# Altium schematic sheet Power.SchDoc(Power)
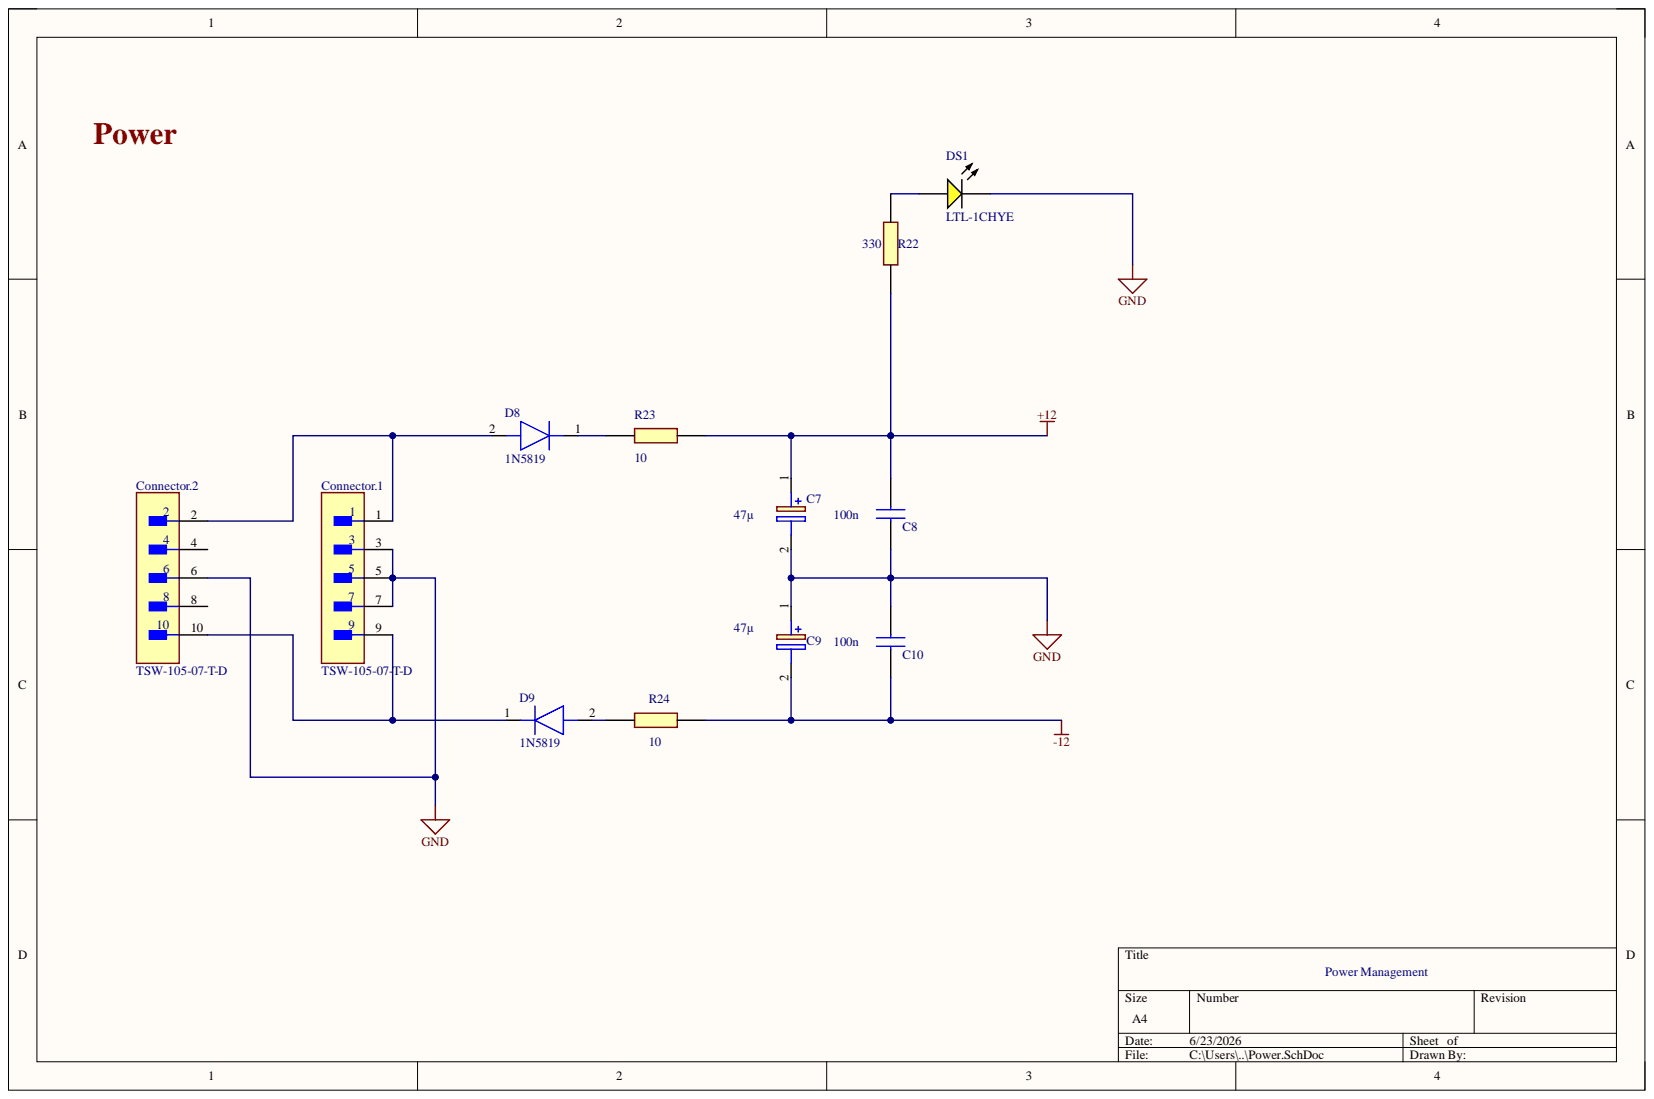
[diagram p0: Power.SchDoc]

## Parts
C7  value 47µ  [CAPPRD350W60D800H1250]  (hidden comment: ESH476M050AG3KA)
C8  value 100n  [K104K15X7RF5TH5]  (hidden comment: K104K15X7RF5TH5)
C9  value 47µ  [CAPPRD350W60D800H1250]  (hidden comment: ESH476M050AG3KA)
C10  value 100n  [K104K15X7RF5TH5]  (hidden comment: K104K15X7RF5TH5)
Connector  TSW-105-07-T-D  [FP-TSW-105-07-T-D-MFG]  (parts .1,.2)
D8  1N5819  [DIOAD1414W86L464D238]
D9  1N5819  [DIOAD1414W86L464D238]
DS1  LTL-1CHYE  [LTST-LTL-1CHYE]
R22  value 330  [RESAD1590W60L630D240]  (hidden comment: MFR-25FTF52-600K)
R23  value 10  [RESAD1590W60L630D240]  (hidden comment: MFR-25FTF52-600K)
R24  value 10  [RESAD1590W60L630D240]  (hidden comment: MFR-25FTF52-600K)

## Nets
+12: C7.1 C8.2 R22.2 R23.2
-12: C9.2 C10.1 R24.2
GND: C7.2 C8.1 C9.1 C10.2 Connector.3 Connector.5 Connector.6 Connector.7 DS1.2
NetConnector_1: Connector.1 Connector.2 D8.2
NetConnector_9: Connector.9 Connector.10 D9.1
NetD8_1: D8.1 R23.1
NetD9_2: D9.2 R24.1
NetDS1_1: DS1.1 R22.1

Not connected: Connector 4 8

## Library data of the parts
C7 C9 = CMP-001-00103-1: Suited for high quality; Manufacturer_Name: KEMET; Component Kind: Standard; Component Type: Standard; Datasheet Link: https://content.kemet.com/datasheets/KEM_A4005_ESH.pdf; Design Item ID: ESH476M050AG3KA; Height: 12.5mm; Manufacturer_Part_Number: ESH476M050AG3KA; Pins: 2; Source: SamacSys.SchLib; Library Name: EMEA - Politecnico di Torino - PoliTek Music
C8 C10 = CMP-001-00088-1: Vishay K104K15X7RF5TH5 100nF Multilayer Ceramic Capacitor MLCC 50V dc +/-10% Tolerance Through Hole; Arrow Part Number: K104K15X7RF5TH5; Arrow Price/Stock: https://www.arrow.com/en/products/k104k15x7rf5th5/vishay?utm_currency=USD&region=europe; Component Kind: Standard; Component Type: Standard; Datasheet Link: https://www.vishay.com/docs/45171/kseries.pdf; Design Item ID: K104K15X7RF5TH5; Height: 6.6mm; Manufacturer_Name: Vishay; Manufacturer_Part_Number: K104K15X7RF5TH5; Mouser Part Number: 594-K104K15X7RF5TH5; Mouser Price/Stock: https://www.mouser.co.uk/ProductDetail/Vishay-BC-Components/K104K15X7RF5TH5?qs=CuWZN%2F5Vbiofhf%252BuZNGw%2Fg%3D%3D; Pins: 2; Source: SamacSys.SchLib; Library Name: EMEA - Politecnico di Torino - PoliTek Music
Connector = CMP-00575-00272450-1: TSW-105-07-T-D Conn Unshrouded Header HDR 10 POS 2.54mm Solder ST Thru-Hole Automotive Bulk; Manufacturer Lifecycle Status: PRODUCTION; NexarPartId: 272450; Connector Type: Male Pin; Gender: Male; Max Current Rating: 7.6A; Max Voltage Rating (AC): 550V; Number of Contacts: 10; Color: Black; Component Kind: Standard; Contact Material: Bronze, Nickel, Tin; Contact Mating Length: 5.842mm; Contact Plating: Tin; Depth: 5.08mm; Height: 8.71mm; Housing Color: Black; Housing Material: Polyester; Lead Free: Lead Free; Lead Pitch: 2.54mm; Length: 12.7mm; Library Name: Altium Content Vault; Lifecycle Status: Production; Max Operating Temperature: 105°C; Min Operating Temperature: -55°C; Mount: Through Hole; Number of Pins: 10; Number of Positions: 10; Number of Rows: 2; Orientation: Straight; Packaging: Bulk; Pitch: 2.54mm; Radiation Hardening: No; REACH SVHC: No SVHC; RoHS: Compliant; Row Spacing: 2.54mm; Termination: Solder; Width: 5.08mm
D8 D9 = CMP-004-00034-1: Schottky barrier diode,1N58191A 40V STMicroelectronics 1N5819; Arrow Part Number: 1N5819; Arrow Price/Stock: https://www.arrow.com/en/products/1n5819/stmicroelectronics?region=nac; Component Kind: Standard; Component Type: Standard; Datasheet Link: http://www.st.com/web/en/resource/technical/document/datasheet/CD00001625.pdf; Design Item ID: 1N5819; Manufacturer_Name: STMicroelectronics; Manufacturer_Part_Number: 1N5819; Mouser Part Number: 511-1N5819; Mouser Price/Stock: https://www.mouser.co.uk/ProductDetail/STMicroelectronics/1N5819?qs=G5AQjGfRJcKKUy9S%252BaTHRQ%3D%3D; Pins: 2; Source: SamacSys.SchLib; Library Name: EMEA - Politecnico di Torino - PoliTek Music
DS1 = CMP-1674-00012-1: Through Hole Lamp, 2.1 V, -55 to 100 degC, 2-Pin THD, RoHS, Bulk; Case\Package: 0603; Colour: White; Forward Current: 20 mA; Forward Voltage: 3.6 V; Lens Style: Diffused; Luminous Intensity: 200 mcd; Power Rating: 78 mW; Viewing Angle: 140 degrees; Case Code (Imperial): 0603; Case Code (Metric): 1608; Component Kind: Standard; ComponentLink1Description: Manufacturer URL; ComponentLink1URL: http://www.us.liteon.com/; ComponentLink2Description: Datasheet; ComponentLink2URL: https://datasheet.ciiva.com/4269/s-110-ltl-1chye-4269126.pdf; DatasheetVersion: Rev. B, 07/2013; Height: 0.6 mm; Illumination Colour: White; Length: 1.6 mm; Library Name: Altium Content Vault; Max Operating Temperature: 85 degC; Min Operating Temperature: -40 degC; Mount: Surface Mount; Nominal Vf: 3.6 V; Package Quantity: 4000; PackageDescription: 2-Pin Through Hole Device, Dia 3.8 mm, Pitch 2.54 mm; PackageReference: LTL-1CHYE; Packaging: Tape and Reel; Pins: 2; Radiation Hardening: No; REACH SVHC: No SVHC; RoHSCompliant: true; Width: 0.8 mm
R22-R24 = CMP-009-00182-2: Metal Film Resistors - Through Hole; Manufacturer_Name: YAGEO; Component Kind: Standard; Component Type: Standard; Datasheet Link: https://www.mouser.sg/datasheet/2/447/YAGEO_MFR_datasheet_2023v3-3324391.pdf; Design Item ID: MFR-25FTF52-600K; Manufacturer_Part_Number: MFR-25FTF52-600K; Mouser Part Number: 603-MFR-25FTF52-600K; Mouser Price/Stock: https://www.mouser.co.uk/ProductDetail/YAGEO/MFR-25FTF52-600K?qs=xZ%2FP%252Ba9zWqYtTZdHR75SUg%3D%3D; Pins: 2; Source: SamacSys.SchLib; Library Name: EMEA - Politecnico di Torino - PoliTek Music
